# Altium schematic sheet Stepper_Driver.SchDoc
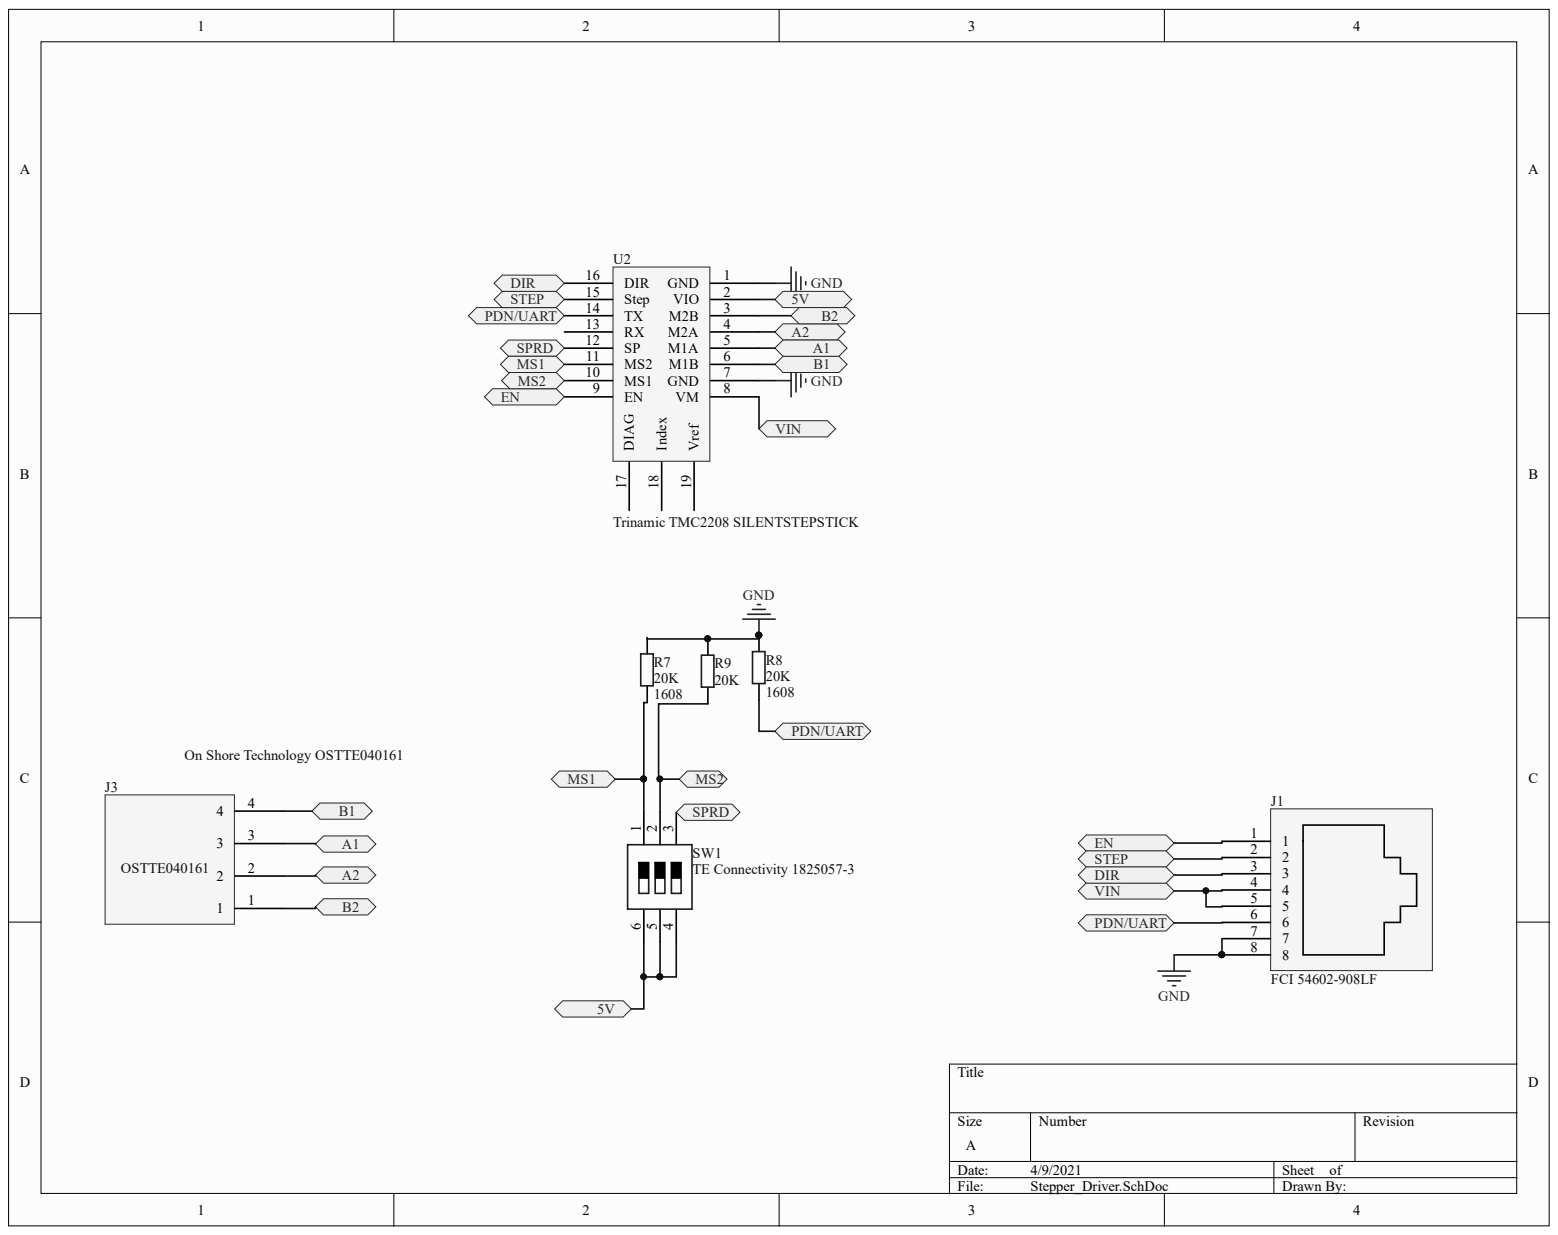
[diagram p0: Stepper_Driver.SchDoc]

## Parts
J1  FCI 54602-908LF  Manufacturer Part Number: 54602-908LF  [PCB-kyusfhn5h9rxu8er00w0-1]
J3  On Shore Technology OSTTE040161  [OSTTE040161]
R7  20K  Size: 1608  [PCB-1ci9j4ma2hnf2m9akcl3-1]
R8  20K  Size: 1608  [PCB-1ci9j4ma2hnf2m9akcl3-1]
R9  20K  [PCB-1ci9j4ma2hnf2m9akcl3-1]
SW1  TE Connectivity 1825057-3  [PCB-chfgz6m5i36qrmmne85a-1]
U2  Trinamic TMC2208 SILENTSTEPSTICK  [PCB-mklgro9ndndi6uycvhjn-1]

## Ports
5V, A1, A2, B1, B2, DIR, EN, MS1, MS2, PDN/UART, SPRD, STEP, VIN

## Nets
GND: J1.7 J1.8 R7.1 R8.1 R9.1 U2.1(GND) U2.7(GND)
5V: SW1.4 SW1.5 SW1.6 U2.2(VIO) ; port 5V
A1: J3.3 U2.5(M1A) ; port A1
A2: J3.2 U2.4(M2A) ; port A2
B1: J3.4 U2.6(M1B) ; port B1
B2: J3.1 U2.3(M2B) ; port B2
DIR: J1.3 U2.16(DIR) ; port DIR
EN: J1.1 U2.9(EN) ; port EN
MS1: R7.2 SW1.1 U2.11(MS2) ; port MS1
MS2: R9.2 SW1.2 U2.10(MS1) ; port MS2
PDN/UART: J1.6 R8.2 U2.14(TX) ; port PDN/UART
SPRD: SW1.3 U2.12(SP) ; port SPRD
STEP: J1.2 U2.15(Step) ; port STEP
VIN: J1.4 J1.5 U2.8(VM) ; port VIN

Not connected: U2 13(RX) 17(DIAG) 18(Index) 19(Vref)

## Library data of the parts
J1 = CMP-1a15d1442034c5d2-8: Conn Modular Jack F 8 POS 2.54mm Solder RA Thru-Hole 8 Terminal 1 Port Box Cat 3; Gender: Male; Lead-Free Status: Lead Free; Number of Contacts: 8; Color: Black; Component Kind: Standard; Contact Material: Phosphor Bronze; Contact Plating: Gold; Library Name: CommunityVault; Mounting Style: Through Hole, PCB; OctopartID: 1a15d1442034c5d2; Octopart URL: http://octopart.com/54602-908lf-fci-39526215; REACH SVHC Compliance: No SVHC; RoHS: Compliant; Size-Height: 12.7 mm; Size-Length: 15.3 mm; Size-Width: 17.7 mm
J3 = CMP-b52b7c252a8f6aec-1: Connector Terminal Block 4 Position..138" /3.5Mm Space Th Top Screw; Number of Positions: 4; Pin Pitch: 3.50 mm; Component Kind: Standard; Housing Material: Nylon; Library Name: CommunityVault; Octopart URL: http://octopart.com/ostte040161-on+shore+technology-309954; Size-Width: 7.00 mm
R7-R9 = CMP-aa1d6305ca5475da-8: Res Thick Film 1.6 x 0.8 mm 20K Ohm 1% 0.1W(1/10W) 100ppm/ C Molded SMD Paper T/R; Manufacturer Part Number: RC0603FR-0720KL; Lead-Free Status: Lead Free; Power Rating: 100 mW; Voltage Rating (DC): 50.0 V; Component Kind: Standard; Library Name: CommunityVault; Mounting Style: Surface Mount; Number of Pins: 2; Packaging: Tape & Reel; Resistance Tolerance: ±1%; RoHS: Compliant; Size-Height: 450 µm; Size-Length: 1.60 mm
SW1 = CMP-3f99f6ac8d38e05e-1: Switch DIP ON OFF SPST 3 Flush Slide 0.1A 20VDC Gull Wing 2000Cycles 2.54mm SMD T/R; Component Kind: Standard; Library Name: CommunityVault
U2 = CMP-4859874e3259979e-1: Step / Direction Driver Board with TMC2209; Component Kind: Standard; Library Name: CommunityVault
